# Altium schematic sheet SDCARD.SchDoc
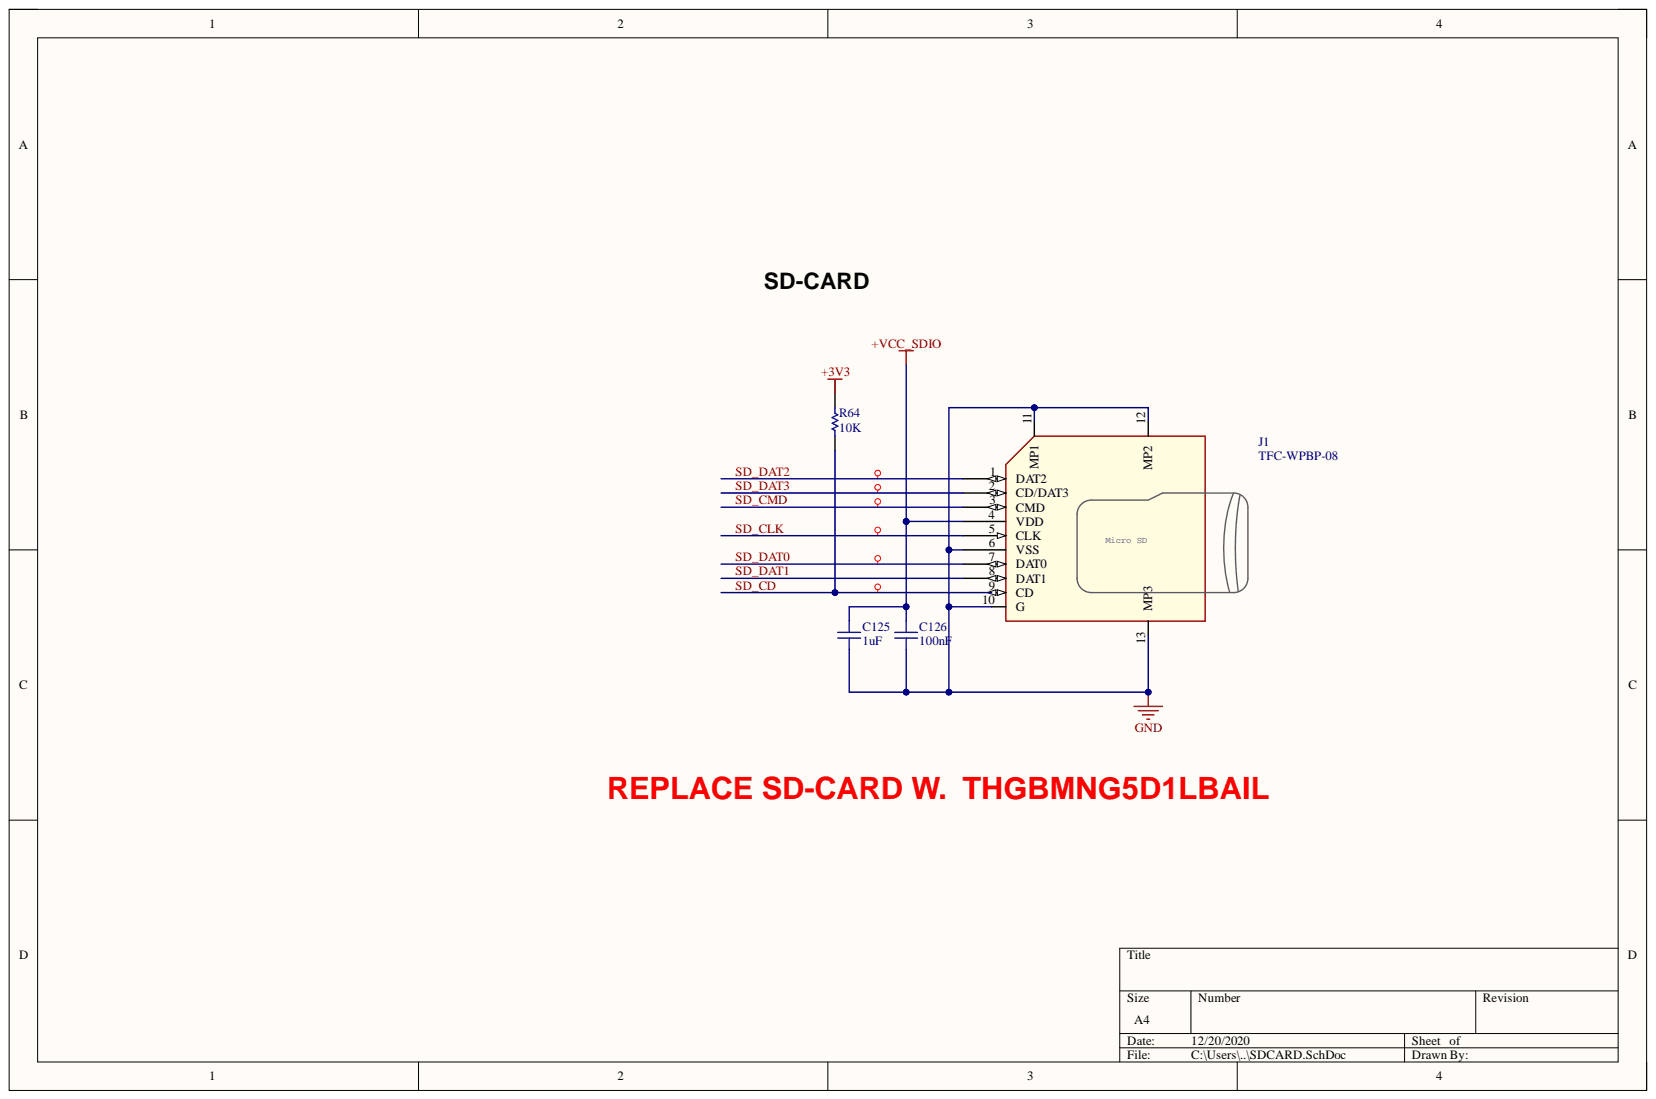
[diagram p0: SDCARD.SchDoc]

## Parts
C125  1uF  [AA-0402]
C126  100nF  [AA-0402]
J1  TFC-WPBP-08  [TFC_WPBP_08]
R64  10K  [AA-0402]

## Nets
+3V3: R64.2
+VCC_SDIO: C125.2 C126.2 J1.4(VDD)
GND: C125.1 C126.1 J1.6(VSS) J1.10(G) J1.11(MP1) J1.12(MP2) J1.13(MP3)
SD_CD: J1.9(CD) R64.1
SD_CLK: J1.5(CLK)
SD_CMD: J1.3(CMD)
SD_DAT0: J1.7(DAT0)
SD_DAT1: J1.8(DAT1)
SD_DAT2: J1.1(DAT2)
SD_DAT3: J1.2(CD/DAT3)

## Library data of the parts
C125 C126 = 00005453: Database Table Name: Capacitor; Component Kind: Standard; Library Name: ALT_DB.DBLIB
J1 = 70000203W: micro SD conectorTOP; push on push off;with boss; 14.8x16.2mm h=1.9mm; Manufacturer: MORETHANALL; Database Table Name: Connectors; Gaminys: 8DEV; Komentaras: $; Allegro PCB Footprint: TFC_WPBP_08; Component Kind: Standard; Data: 2013-06-06; Library Name: ALT_DB.DBLIB; Manufacturer Part Number: TFC-WPBP-08; Part Number: 70000203W; Part Type: Connector\micro SD CARD; SMD: Yes; Datasheet: E:\pdf\JUNGTYS\107971654c901d8921f4c.pdf
R64 = 10012076Wa: Database Table Name: Resistor; Component Kind: Standard; Library Name: ALT_DB.DBLIB; Signal Integrity: Res; Simulation: RESISTOR; Value: 10K
